# Altium schematic sheet 08-Power.SchDoc(08-Power)
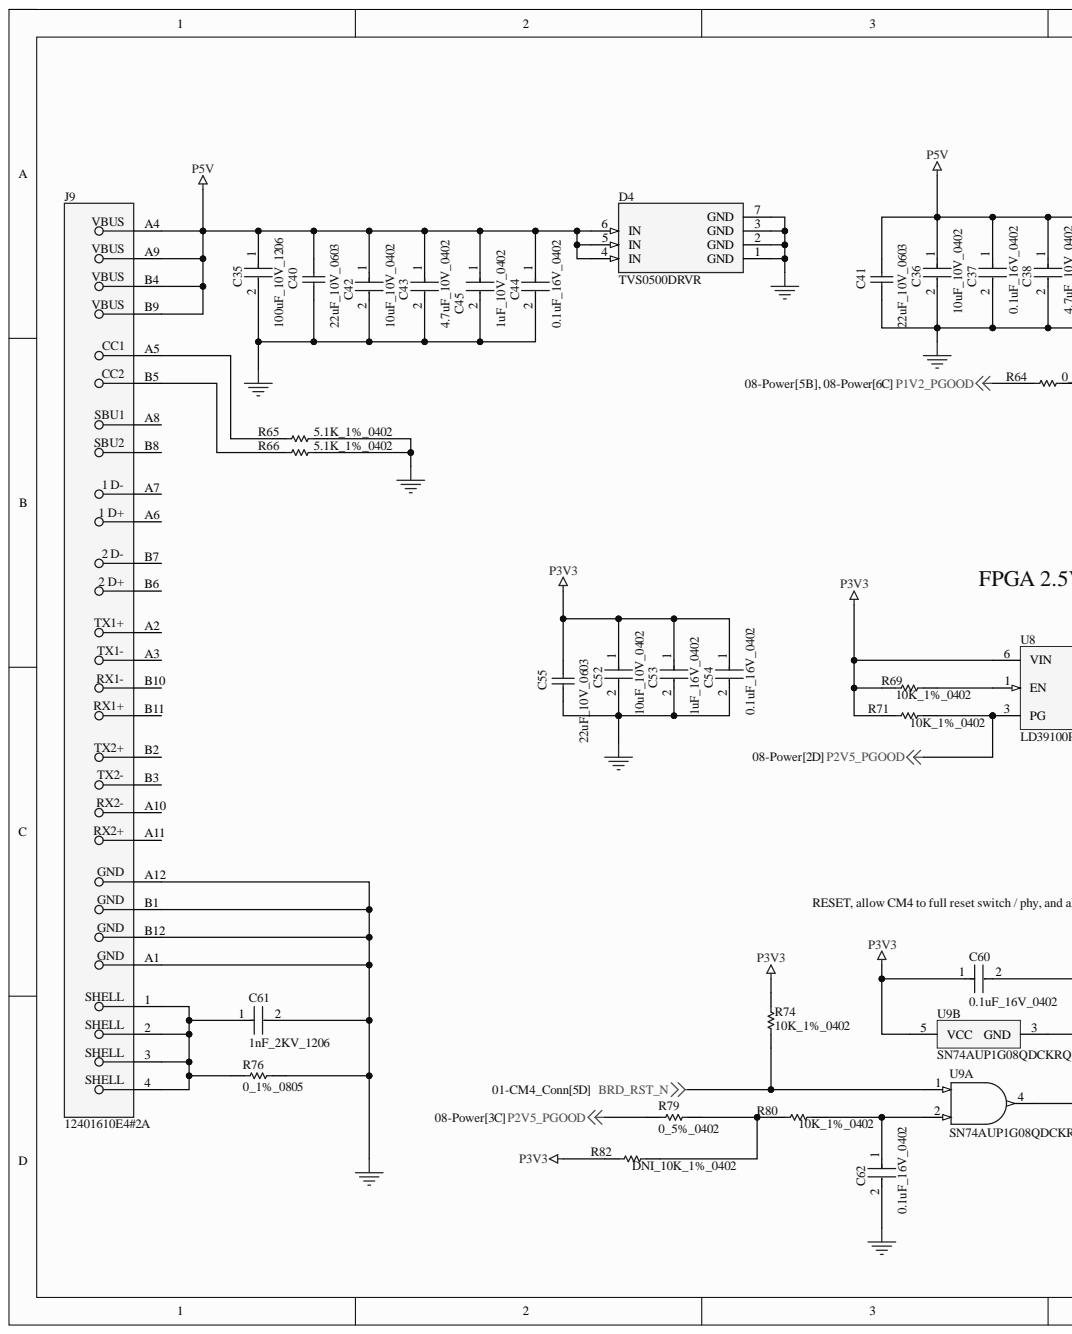
[diagram p0: 08-Power.SchDoc, left]
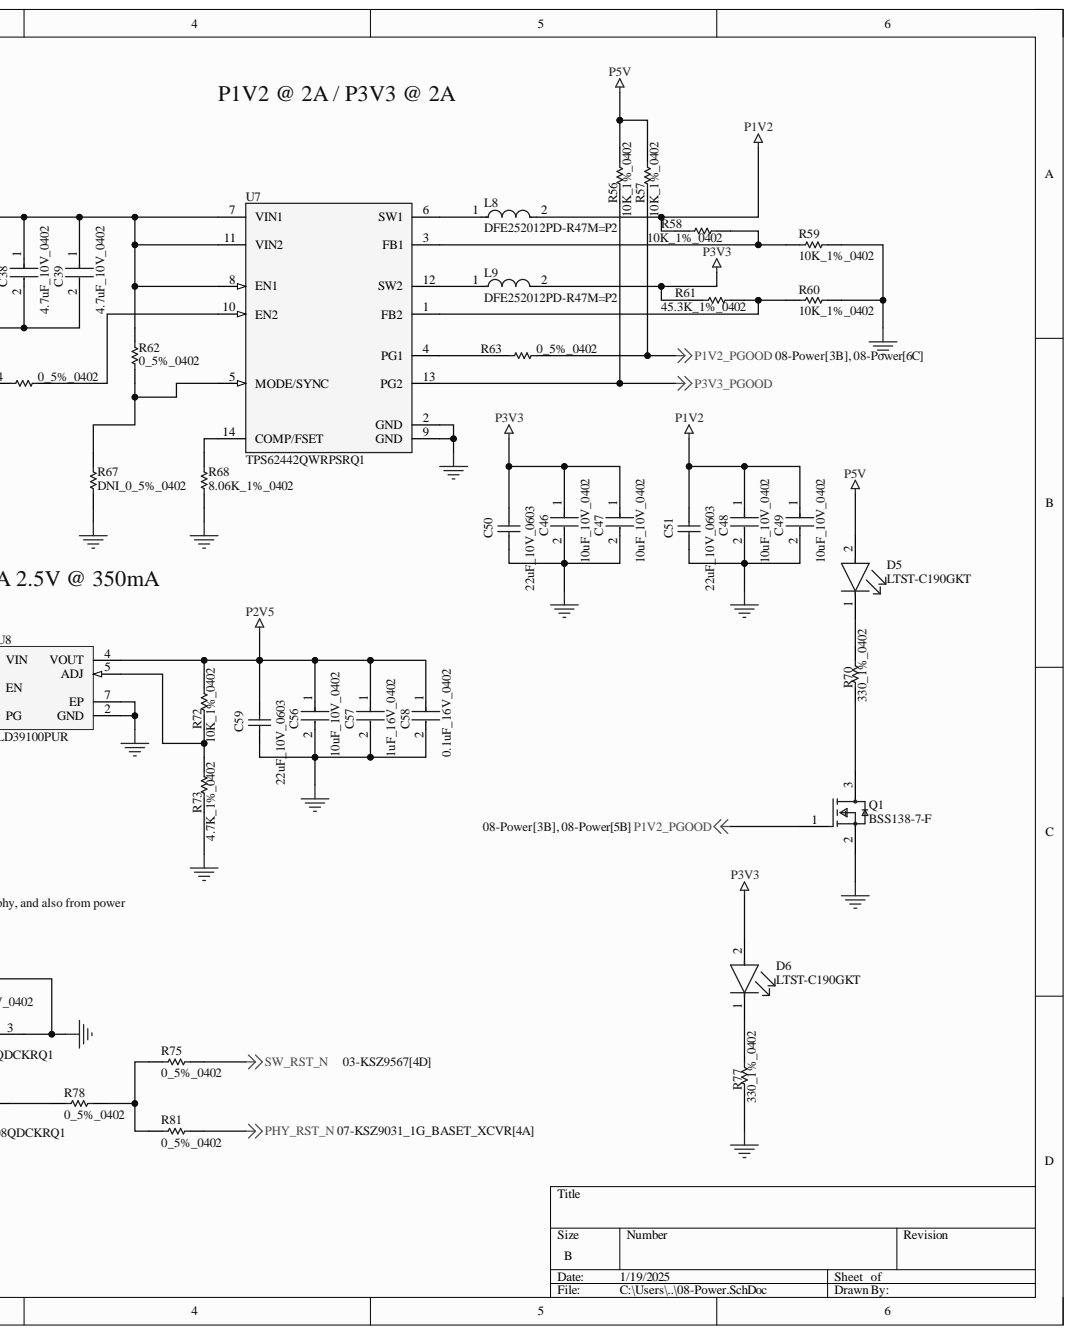
[diagram p1: 08-Power.SchDoc, right]

## Parts
C35  100uF_10V_1206  [FP-C3216-160-0_3-IPC_C]
C36  10uF_10V_0402  [FP-CL05A106MP5NUNC-MFG]
C37  0.1uF_16V_0402  [FP-C0402-BB-MFG]
C38  4.7uF_10V_0402  [FP-C1005-050-0_15-MFG]
C39  4.7uF_10V_0402  [FP-C1005-050-0_15-MFG]
C40  22uF_10V_0603  [CAPC1608X100X20ML10]
C41  22uF_10V_0603  [CAPC1608X100X20ML10]
C42  10uF_10V_0402  [FP-CL05A106MP5NUNC-MFG]
C43  4.7uF_10V_0402  [FP-C1005-050-0_15-MFG]
C44  0.1uF_16V_0402  [FP-C0402-BB-MFG]
C45  1uF_10V_0402  [FP-CL511-IPC_C]
C46  10uF_10V_0402  [FP-CL05A106MP5NUNC-MFG]
C47  10uF_10V_0402  [FP-CL05A106MP5NUNC-MFG]
C48  10uF_10V_0402  [FP-CL05A106MP5NUNC-MFG]
C49  10uF_10V_0402  [FP-CL05A106MP5NUNC-MFG]
C50  22uF_10V_0603  [CAPC1608X100X20ML10]
C51  22uF_10V_0603  [CAPC1608X100X20ML10]
C52  10uF_10V_0402  [FP-CL05A106MP5NUNC-MFG]
C53  1uF_16V_0402  [FP-0402-L_1_0_0_05-W_0_5-IPC_A]
C54  0.1uF_16V_0402  [FP-C0402-BB-MFG]
C55  22uF_10V_0603  [CAPC1608X100X20ML10]
C56  10uF_10V_0402  [FP-CL05A106MP5NUNC-MFG]
C57  1uF_16V_0402  [FP-0402-L_1_0_0_05-W_0_5-IPC_A]
C58  0.1uF_16V_0402  [FP-C0402-BB-MFG]
C59  22uF_10V_0603  [CAPC1608X100X20ML10]
C60  0.1uF_16V_0402  [FP-C0402-BB-MFG]
C61  1nF_2KV_1206  [FP-CC1206-0_3-1_45-IPC_C]
C62  0.1uF_16V_0402  [FP-C0402-BB-MFG]
D4  TVS0500DRVR  [FP-DRV0006A-MFG]
D5  LTST-C190GKT  [FP-LTST-C190GKT-MFG]
D6  LTST-C190GKT  [FP-LTST-C190GKT-MFG]
J9  12401610E4#2A  [FP-12401610E4#2A-MFG]
L8  DFE252012PD-R47M=P2  [FP-DFE252012C-IPC_A]
L9  DFE252012PD-R47M=P2  [FP-DFE252012C-IPC_A]
Q1  BSS138-7-F  [FP-SOT23_Standard-MFG]
R56  10K_1%_0402  [RESC1005X40X25NL10T10]
R57  10K_1%_0402  [RESC1005X40X25NL10T10]
R58  10K_1%_0402  [RESC1005X40X25NL10T10]
R59  10K_1%_0402  [RESC1005X40X25NL10T10]
R60  10K_1%_0402  [RESC1005X40X25NL10T10]
R61  45.3K_1%_0402  [RESC0402(1005)_L]
R62  0_5%_0402  [RESC1005X40X25NL05T10]
R63  0_5%_0402  [RESC1005X40X25NL05T10]
R64  0_5%_0402  [RESC1005X40X25NL05T10]
R65  5.1K_1%_0402  [RESC1005X40X25NL05T10]
R66  5.1K_1%_0402  [RESC1005X40X25NL05T10]
R67  DNI_0_5%_0402  [RESC1005X40X25NL05T10]  (no BOM)
R68  8.06K_1%_0402  [RESC1005X40X25ML05T05]
R69  10K_1%_0402  [RESC1005X40X25NL10T10]
R70  330_1%_0402  [RESC1005X40X25NL10T10]
R71  10K_1%_0402  [RESC1005X40X25NL10T10]
R72  10K_1%_0402  [RESC1005X40X25NL10T10]
R73  4.7K_1%_0402  [RESC1005X40X25NL10T10]
R74  10K_1%_0402  [RESC1005X40X25NL10T10]
R75  0_5%_0402  [RESC1005X40X25NL05T10]
R76  0_1%_0805  [RESC2013X60X35NL10T20]
R77  330_1%_0402  [RESC1005X40X25NL10T10]
R78  0_5%_0402  [RESC1005X40X25NL05T10]
R79  0_5%_0402  [RESC1005X40X25NL05T10]
R80  10K_1%_0402  [RESC1005X40X25NL10T10]
R81  0_5%_0402  [RESC1005X40X25NL05T10]
R82  DNI_10K_1%_0402  [RESC1005X40X25NL10T10]  (no BOM)
U7  TPS62442QWRPSRQ1  [FP-RQR0014A-MFG]
U8  LD39100PUR  [DFN6D_M]
U9  SN74AUP1G08QDCKRQ1  [DCK0005A_L]  (parts A,B)

## Nets
BRD_RST_N: R74.2 U9.1
GND: C35.2 C36.2 C37.2 C38.2 C39.2 C40.1 C41.1 C42.2 C43.2 C44.2 C45.2 C46.2 C47.2 C48.2 C49.2 C50.1 C51.1 C52.2 C53.2 C54.2 C55.1 C56.2 C57.2 C58.2 C59.1 C60.2 C61.2 C62.2 D4.1(GND) D4.2(GND) D4.3(GND) D4.7(GND) J9.A1 J9.A12 J9.B1 J9.B12 Q1.2 R59.1 R60.1 R65.2 R66.2 R67.1 R68.1 R73.1 R76.2 R77.1 U7.2(GND) U7.9(GND) U8.2(GND) U8.7(EP) U9.3(GND)
P1V2: C48.1 C49.1 C51.2 L8.2 R58.2
P1V2_PGOOD: Q1.1 R57.1 R63.2 R64.1
P2V5: C56.1 C57.1 C58.1 C59.2 R72.2 U8.4(VOUT)
P2V5_PGOOD: R71.1 R79.2 U8.3(PG)
P3V3: C46.1 C47.1 C50.2 C52.1 C53.1 C54.1 C55.2 C60.1 D6.2 L9.2 R61.1 R69.2 R71.2 R74.1 R82.2 U8.6(VIN) U9.5(VCC)
P3V3_PGOOD: R56.1 U7.13(PG2)
P5V: C35.1 C36.1 C37.1 C38.1 C39.1 C40.2 C41.2 C42.1 C43.1 C44.1 C45.1 D4.4(IN) D4.5(IN) D4.6(IN) D5.2 J9.A4 J9.A9 J9.B4 J9.B9 R56.2 R57.2 R62.2 U7.7(VIN1) U7.8(EN1) U7.11(VIN2)
PHY_RST_N: R81.1
SW_RST_N: R75.1
NetC61_1: C61.1 J9.1 J9.2 J9.3 J9.4 R76.1
NetC62_1: C62.1 R80.1 U9.2
NetD5_1: D5.1 R70.2
NetD6_1: D6.1 R77.2
NetJ9_A5: J9.A5 R65.1
NetJ9_B5: J9.B5 R66.1
NetL8_1: L8.1 U7.6(SW1)
NetL9_1: L9.1 U7.12(SW2)
NetQ1_3: Q1.3 R70.1
NetR58_1: R58.1 R59.2 U7.3(FB1)
NetR60_2: R60.2 R61.2 U7.1(FB2)
NetR62_1: R62.1 R67.2 U7.5(MODE/SYNC)
NetR63_1: R63.1 U7.4(PG1)
NetR64_2: R64.2 U7.10(EN2)
NetR68_2: R68.2 U7.14(COMP/FSET)
NetR69_1: R69.1 U8.1(EN)
NetR72_1: R72.1 R73.2 U8.5(ADJ)
NetR75_2: R75.2 R78.1 R81.2
NetR78_2: R78.2 U9.4
NetR79_1: R79.1 R80.2 R82.1

Not connected: J9 A2 A3 A6 A7 A8 A10 A11 B2 B3 B6 B7 B8 B10 B11

## Library data of the parts
C35 = CMP-2000-05396-3: Multilayer Ceramic Capacitors 100uF ±20% 10V X5R SMD 1206; Capacitance: 100uF; Case Code (Imperial): 1206; Case Code (Metric): 3216; Case/Package: 1206; Component Kind: Standard; Construction: Flat; Depth: 1.6mm; Dielectric: X5R; Dielectric Material: Ceramic; Height: 1.6mm; Lead Free: Lead Free; Length: 3.2mm; Library Name: Altium Content Vault; Max Operating Temperature: 85°C; Min Operating Temperature: -55°C; Mount: Surface Mount; Package Quantity: 1; Packaging: Tape and Reel; Radiation Hardening: No; REACH SVHC: No SVHC; Resistor Type: Ceramic; RoHS Compliant: Yes; Series: C; Termination: SMD/SMT; Thickness: 0.075inch; Tolerance: 20%; Voltage: 10V; Voltage Rating: 10V; Voltage Rating (DC): 10V; Weight: 0.000571oz; Width: 1.6mm
C36 C42 C46-C49 C52 C56 = CMP-13271-000002-1: CAP CER 10UF 10V X5R 0402; Manufacturer: Samsung; Manufacturer Part Number: CL05A106MP5NUNC; Material: Ceramic; Temperature Coefficient: 15%; Tolerance: 20%; Automotive: No; Capacitance: 10uF; Category: Cap; Component Kind: Standard; ComponentLink2Description: Datasheet; ComponentLink2URL: http://www.samsungsem.com/kr/support/product-search/mlcc/__icsFiles/afieldfile/2019/05/10/19-CL05A106MP5NUNC.pdf; Device Class L1: Passive Components; Device Class L2: Capacitors; Device Class L3: Ceramic Capacitors; Digikey Description: CAP CER 10UF 10V X5R 0402; Height: 0.7mm; Ipc Land Pattern Name: CAPC10050X50; Lead Free: Yes; Library Name: Altium Content Vault; Octopart: https://octopart.com/cl05a106mp5nunc-samsung-22457395; Package: CL05A106MP5NUNC; RoHS: TRUE; Temperature: -55°C to +85°C; Temperature Characteristic: X5R; Temperature Range High: +85°C; Temperature Range Low: -55°C; Value: 10uF; Voltage Rating: 10V
C37 C44 C54 C58 C60 C62 = CMP-1034-02068-3: CAP CER 0.1UF 16V 5% X7R 0402; Manufacturer: Kemet; Manufacturer Part Number: C0402C104J4RACTU; Capacitance: 0.10 μF; Material: Ceramic; Temperature Coefficient: 15%; Tolerance: 0.05; Value: 0.10 μF; Automotive: No; Category: Cap; Component Kind: Standard; ComponentLink2Description: Datasheet; ComponentLink2URL: https://content.kemet.com/datasheets/KEM_C1002_X7R_SMD.pdf; Device Class L1: Passive Components; Device Class L2: Capacitors; Device Class L3: Ceramic Capacitors; Digikey Description: CAP CER 0.1UF 16V 5% X7R 0402; Height: 0.55mm; Ipc Land Pattern Name: CAPC10050X50; Lead Free: Yes; Library Name: Altium Content Vault; Mouser Description: CAP CER 0.1UF 16V X7R 0402; Octopart: https://octopart.com/c0402c104j4ractu-kemet-12573893; Package: C0402-BB; RoHS: TRUE; Temperature: -55°C to +125°C; Temperature Characteristic: X7R; Temperature Range High: +125°C; Temperature Range Low: -55°C; Voltage Rating: 16 V
C38 C39 C43 = CMP-2000-05997-2: CAP CER 4.7UF 10V X5R 0402; Dielectric Material: Ceramic; Tolerance: 10%; Capacitance: 4.7uF; Case Code (Imperial): 0402; Case Code (Metric): 1005; Case/Package: 0402; Component Kind: Standard; Dielectric: X5R; Lead Free: Lead Free; Length: 0.039inch; Library Name: Altium Content Vault; Max Operating Temperature: 85°C; Min Operating Temperature: -55°C; Mount: Surface Mount; Package Quantity: 10000; Packaging: Cut Tape; REACH SVHC: No SVHC; RoHS Compliant: Yes; Series: C; Thickness: 0.022inch; Voltage Rating: 10V; Voltage Rating (DC): 10V; Width: 0.02inch
C40 C41 C50 C51 C55 C59 = CMP-2000-05441-1: Chip Capacitor, 22 uF, +/- 20%, 10 V, -55 to 85 degC, 0603 (1608 Metric), RoHS, Tape and Reel; Manufacturer: TDK; PCN Part Number: MLCCPartNumberChange30/Nov/2012; Capacitance: 22µF; Design Resources: 20WNon-IsolatedBuckLEDDriverUsingPLLNK460KG; Kits: 445-9014-KIT-ND-KITMLCC100PF-22UF060310-50V; Online Catalog: CSeries,GeneralPurpose; Other Names: 445-9077-1; Product Training Modules: CSeriesGeneralApplicationsSEAT,CCV,andTVCLDesignToolsGuidetoReplacinganElectrolyticCapacitorwithanMLCC; Temperature Coefficient: X5R; Voltage - Rated: 10V; Applications: GeneralPurpose; Category: Capacitors; Component Kind: Standard; Datasheet URL: http://download.siliconexpert.com/pdfs/2015/4/21/15/54/45/779/tdk_/manual/37024850441143360mlcc_commercial_general_en.pdf; Datasheet Version: Mar-15; Family: CeramicCapacitors; Features: -; Height - Seated (Max): -; Lead Spacing: -; Lead Style: -; Library Name: Altium Content Vault; Manufacturer URL: http://www.tdk.com/; Mounting Type: SurfaceMount,MLCC; Operating Temperature: -55degC~85degC; Package / Case: 0603(1608Metric); Package Description: 2-Pin Surface Mount Device, Body 1.6 x 0.8 mm; Package Reference: 603; Packaging: CutTape(CT); Ratings: -; RoHS Information: RoHSCertificate-MLCC; Series: C; Size / Dimension: 0.063"Lx0.031"W(1.60mmx0.80mm); Standard Package: 1; Thickness (Max): 0.035"(0.90mm); Tolerance: ±20%
C45 = CMP-13271-001936-1: Cap Ceramic 1uF 10V X5R ±10% SMD 0402 +85°C Paper T/R; Capacitance: 1uF; Case Code (Imperial): 0402; Case Code (Metric): 1005; Case/Package: 0402; Component Kind: Standard; Contact Plating: Tin; Depth: 0.5mm; Dielectric: X5R; Height: 0.5mm; Length: 1mm; Library Name: Altium Content Vault; Material: Ceramic; Max Operating Temperature: 85°C; Min Operating Temperature: -55°C; Mount: Surface Mount; Package Quantity: 1; Packaging: Tape and Reel; Radiation Hardening: No; Thickness: 0.022inch; Tolerance: 10%; Voltage Rating: 10V; Width: 0.02inch
C53 C57 = CMP-14477-000104-2: None; Capacitance: 1uF; Dielectric: X5R; Tolerance: 10%; Voltage Rating: 16V; Case Code (Imperial): 0402; Case Code (Metric): 1005; Case/Package: 0402; Component Kind: Standard; Construction: Flat; Depth: 0.5mm; Halogen Free: Halogen Free; Height: 0.5mm; Length: 1mm; Library Name: Altium Content Vault; Max Operating Temperature: 85°C; Min Operating Temperature: -55°C; Mount: Surface Mount; Number of Pins: 2; Package Quantity: 1; Packaging: Tape and Reel; Radiation Hardening: No; RoHS Compliant: Yes; Series: M; Termination: SMD/SMT; Thickness: 0.022inch; Voltage Rating (DC): 16V; Width: 0.02inch
C61 = CMP-2000-05436-2: CAP CER 1000PF 2KV X7R 1206; Capacitance: 1nF; Dissipation Factor: 2.5%; Insulation Resistance: 10GR; Tolerance: 10%; Case Code (Imperial): 1206; Case Code (Metric): 3216; Case/Package: 1206; Component Kind: Standard; Construction: Flat; Depth: 1.6mm; Dielectric: X7R; Height: 1.25mm; Lead Free: Lead Free; Length: 3.2mm; Library Name: Altium Content Vault; Max Operating Temperature: 125°C; Min Operating Temperature: -55°C; Mount: Surface Mount; Number of Pins: 2; Package Quantity: 1; Packaging: Tape and Reel; Radiation Hardening: No; Resistor Type: Ceramic; RoHS Compliant: Yes; Series: CC; Termination: SMD/SMT; Thickness: 0.057inch; Voltage: 2kV; Voltage Rating: 2kV; Voltage Rating (DC): 2kV; Width: 0.063inch
D4 = CMP-04989-000008-1: UNIDIR PRECISION SURGE DIODE; Clamping Voltage: 9.5V; Min Breakdown Voltage: 7.5V; Number of Channels: 1; Peak Pulse Current: 43A; Peak Pulse Power: 400W; Power Line Protection: No; Reverse Standoff Voltage: 5V; Component Kind: Standard; Library Name: Altium Content Vault; Max Operating Temperature: 125°C; Min Operating Temperature: -40°C; Mount: Surface Mount; Number of Unidirectional Channels: 1; Packaging: Tape and Reel; Resistor Type: Zener; RoHS Compliant: Yes
D5 D6 = CMP-22017-000005-2: LED GREEN CLEAR CHIP SMD; Dominant Wavelength: 569nm; Forward Current: 30mA; Forward Voltage: 2.1V; Lens Color: Colorless; Luminous Intensity: 6mcd; Number of Elements: 1; Power Dissipation: 100mW; Reverse Voltage: 5V; Test Current: 10mA; Viewing Angle: 130°; Voltage: 2V; Wavelength: 565nm; Case Code (Imperial): 0603; Case Code (Metric): 1608; Case/Package: 0603; Color: Green; Component Kind: Standard; Depth: 0.8mm; Height: 0.8mm; Illumination Color: Green; Lead Free: Lead Free; Length: 1.6mm; Lens Style: Clear; Lens Transparency: Clear; Library Name: Altium Content Vault; Max Operating Temperature: 85°C; Min Operating Temperature: -55°C; Mount: Surface Mount; Number of LEDs: 1; Number of Pins: 2; Package Quantity: 1; Packaging: Tape and Reel; Power Rating: 100mW; Radiation Hardening: No; Width: 0.8mm
J9 = CMP-02355-000003-1: CONN RCP USB3.1 TYPEC 24P SMD RA; Connector Type: USB; Gender: Receptacle; Number of Contacts: 24; Component Kind: Standard; Library Name: Altium Content Vault; Mount: Surface Mount; Number of Pins: 24; Packaging: Cut Tape
L8 L9 = CMP-06042-015149-1: Shielded Wirewound Inductor 0.47uH 20% 4000mA 0.027Ω 1008 (2520); Component Kind: Standard; Library Name: Altium Content Vault
Q1 = CMP-2000-04930-2: NexarPartId: 335900; Continuous Drain Current (ID): 200mA; Current: 2A; Drain to Source Breakdown Voltage: 50V; Drain to Source Resistance: 1.4Ω; Element Configuration: Single; Fall Time: 25ns; Gate to Source Voltage (Vgs): 20V; Input Capacitance: 50pF; Max Junction Temperature (Tj): 150°C; Max Power Dissipation: 300mW; Nominal Vgs: 1.2V; Number of Channels: 1; Rds On Max: 3.5Ω; Rise Time: 10ns; Turn-Off Delay Time: 20ns; Case/Package: SOT-23; Component Kind: Standard; Contact Plating: Tin; Current Rating: 200mA; Drain to Source Voltage (Vdss): 50V; Height: 1.15mm; Lead Free: Lead Free; Length: 2.9mm; Library Name: Altium Content Vault; Max Operating Temperature: 150°C; Min Operating Temperature: -55°C; Mount: Surface Mount; Number of Elements: 1; Number of Pins: 3; Packaging: Cut Tape; Power Dissipation: 300mW; REACH SVHC: No SVHC; Resistance: 3.5Ω; RoHS: Compliant; Schedule B: 8541210080, 8541210080; Threshold Voltage: 1.2V; Turn-On Delay Time: 20ns; Voltage: 50V; Voltage Rating (DC): 50V; Weight: 7.994566mg; Width: 1.3mm
R56-R60 R69 R71 R72 R74 R80 R82 = CMP-2002-04694-1: Manufacturer: Bourns; Part Number: CR0402-FX-1002GLF; Library Name: Altium Content Vault
  R56-R60 R69 R71 R72 R74 R80: Component Kind: Standard
R61 = CMP-1011-00697-1: 45K3 0.063W 1% 0402 (1005 Metric)  SMD; Case-EIA: 0402; Case-Metric: 1005; Value: 45300.00 Ohm; Component Kind: Standard; Library Name: Altium Content Vault; Power: 0.063 W; Technology: SMT; Tolerance: 1 %
R62-R64 R67 R75 R78 R79 R81 = CMP-2002-06457-1: Manufacturer: Panasonic; Part Number: ERJ-U020R00X; Library Name: Altium Content Vault
  R62-R64 R75 R78 R79 R81: Component Kind: Standard
R65 R66 = CMP-2002-07753-1: Manufacturer: Yageo / Phycomp; Part Number: RC0402FR-075K1L; Component Kind: Standard; Library Name: Altium Content Vault
R68 = CMP-2002-00253-3: Chip Resistor, 8.06 KOhm, +/- 1%, 63 mW, -55 to 155 degC, 0402 (1005 Metric), RoHS, Tape and Reel; Manufacturer: Basic Passives; PartNumber: CRCW04028K06FKED; Case\/Package: 0402; Power Rating: 63 mW; Voltage Rating: 50 V; Case Code (Imperial): 0402; Case Code (Metric): 1005; Component Kind: Standard; ComponentLink1Description: Manufacturer URL; ComponentLink1URL: http://www.vishay.com/; ComponentLink2Description: Datasheet; ComponentLink2URL: http://www.vishay.com/docs/20035/dcrcwe3.pdf; DatasheetVersion: 06/2012; Height: 0.35 mm; Length: 1 mm; Library Name: Altium Content Vault; Max Operating Temperature: 155 degC; Min Operating Temperature: -55 degC; Mount: Surface Mount; Mounting Technology: Surface Mount; Package Quantity: 10000; PackageDescription: 2-Pin Surface Mount Device, Body 1 x 0.5 mm; PackageReference: 0402; Packaging: Tape and Reel; Pins: 2; Radiation Hardening: No; REACH SVHC: Unknown; Resistance: 8.06 KOhm; RoHSCompliant: true; Tolerance: 1%; Weight: 2.3E-05oz; Width: 0.5 mm
R70 R77 = CMP-2002-05853-1: Manufacturer: Panasonic; Part Number: ERA-2AED331X; Component Kind: Standard; Library Name: Altium Content Vault
R73 = CMP-2002-05217-1: Manufacturer: Bourns; Part Number: CR0402-FX-4701GLF; Component Kind: Standard; Library Name: Altium Content Vault
R76 = CMP-2001-04478-1: Manufacturer: Yageo; Part Number: AC0805FR-070RL; Component Kind: Standard; Library Name: Altium Content Vault
U7 = CMP-04918-000654-1: Adjustable-Frequency Dual Step-Down Converter, 2.7-6V VIN, 0.6-5.5V 2A VOUT, VQFN-HR14; Component Kind: Standard; Library Name: Altium Content Vault
U8 = CMP-0244-00287-1: 1 A Low Quiescent Current Low Noise Voltage Regulator, Adjustable from 0.8V, 6-Pin VFDFPN, Tape and Reel; Component Kind: Standard; ComponentLink1Description: Manufacturer URL; ComponentLink1URL: http://www.st.com; ComponentLink2Description: Datasheet; ComponentLink2URL: http://www.st.com/internet/com/TECHNICAL_RESOURCES/TECHNICAL_LITERATURE/DATASHEET/CD00233788.pdf; DatasheetVersion: 1/10/2011; Library Name: Altium Content Vault; PackageDescription: 6-Pin Plastic, Dual LLP, 3 x 3 mm Body, 0.95 mm Pitch, Exposed Pad; PackageReference: DFN6D; PackageVersion: 2008
U9 = CMP-0859-00286-3: Automotive Catalog Low-Power Single 2-Input Positive-AND Gate, DCK0005A, LARGE T&R; Generic Part Number: SN74AUP1G08-Q1; Manufacturer: Texas Instruments; 3-State Output: No; Bits(#): 1; F @ Nom Voltage(Max)(Mhz): 100; Gate Type: AND; ICC @ Nom Voltage(Max)(mA): 0.0009; Input Type: CMOS; Logic: True; Output Drive (IOL/IOH)(Max)(mA): 20/-20; Technology Family: AUP; tpd @ Nom Voltage(Max)(ns): 32.8,20.9,14.2,11,7.6,6.2; VCC(Max)(V): 3.6; VCC(Min)(V): 0.8; Voltage(Nom)(V): 0.8,1.2,1.5,1.8,2.5,3.3; Component Kind: Standard; DatasheetVersion: SCES847; Library Name: Altium Content Vault; Operating Temperature Range(C): -40 to 125; Output Type: CMOS; PackageReference: DCK0005A; Rating: Automotive; Schmitt Trigger: No; Sub-Family: AND Gate
